# Altium schematic sheet main.SchDoc(main)
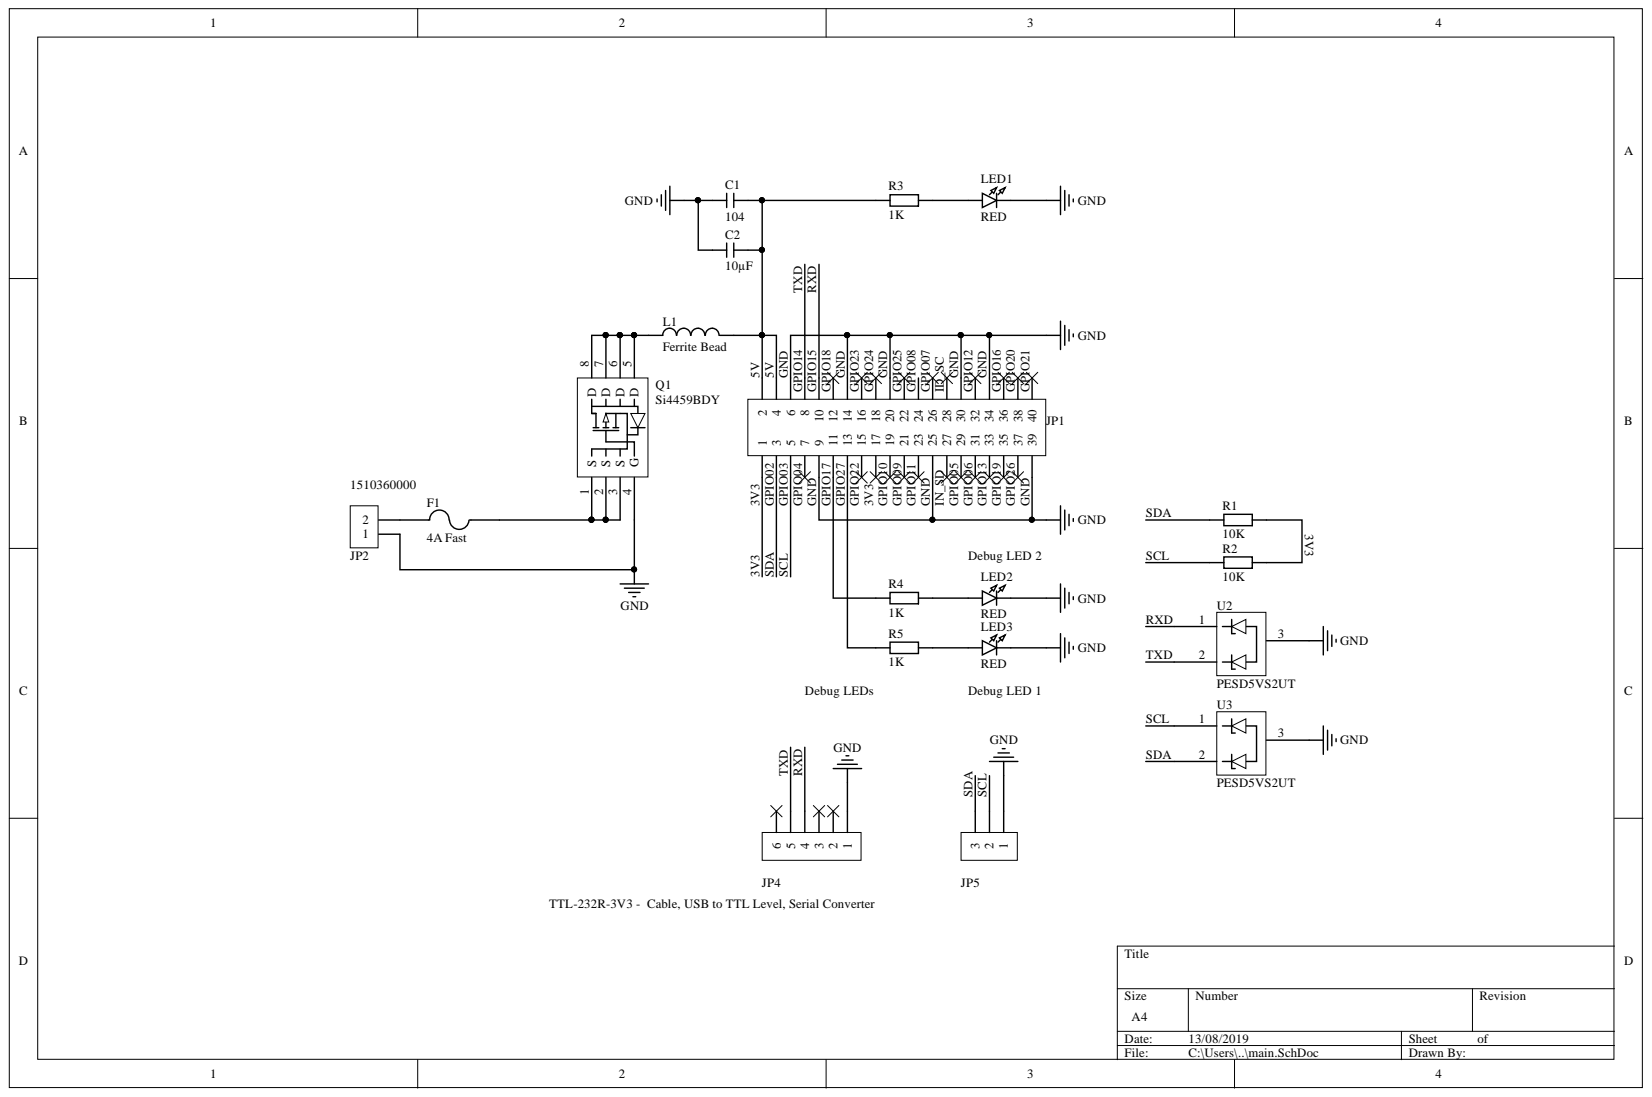
[diagram p0: main.SchDoc]

## Parts
C1  104  [C 0603_L]
C2  10µF  [C 1210_L]
F1  4A Fast  [Omni-blok]
JP1  Header 2X11  [HDR2.54-LI-2x20P]  (value not drawn)
JP2  HDR-1X2  [KF2EDGK5.08-WI-2P]  (value not drawn)
JP4  HDR-1X6  [HDR2.54-LI-6P]  (value not drawn)
JP5  HDR-1X3  [HDR2.54-LI-3P]  (value not drawn)
L1  Ferrite Bead  [L 0805_L]
LED1  RED  [LED 0805R]
LED2  RED  [LED 0805R]
LED3  RED  [LED 0805R]
Q1  Si4459BDY  [SOP8_N]
R1  10K  [R 0805_L]
R2  10K  [R 0805_L]
R3  1K  [R 0805_L]
R4  1K  [R 0805_L]
R5  1K  [R 0805_L]
U2  PESD5VS2UT  [SOT23-3N]
U3  PESD5VS2UT  [SOT23-3N]

## Nets
GND: C1.2 C2.2 JP1.6 JP1.9 JP1.14 JP1.20 JP1.25 JP1.30 JP1.34 JP1.39 JP2.1 JP4.1 JP5.1 LED1.2 LED2.2 LED3.2 Q1.4(G) U2.3 U3.3
3V3: JP1.1 R1.2 R2.2
RXD: JP1.10 JP4.4 U2.1
SCL: JP1.5 JP5.2 R2.1 U3.1
SDA: JP1.3 JP5.3 R1.1 U3.2
TXD: JP1.8 JP4.5 U2.2
NetC1_1: C1.1 C2.1 JP1.2 JP1.4 L1.2 R3.1
NetF1_1: F1.1 JP2.2
NetF1_2: F1.2 Q1.1(S) Q1.2(S) Q1.3(S)
NetJP1_11: JP1.11 R4.1
NetJP1_13: JP1.13 R5.1
NetL1_1: L1.1 Q1.5(D) Q1.6(D) Q1.7(D) Q1.8(D)
NetLED1_1: LED1.1 R3.2
NetLED2_1: LED2.1 R4.2
NetLED3_1: LED3.1 R5.2

Not connected: JP1 7 12 15 16 17 18 19 21 22 23 24 26 27 28 29 31 32 33 35 36 37 38 40; JP4 2 3 6

## Library data of the parts
C1 C2 = C: 无极性贴片电容; 版权归属: 源创客; 学习资源: www.ooocke.com; Library Name: 3.1   - 电阻-电容-电感.SchLib; Component Kind: Standard; BOM名称: 无极性贴片电容
F1 = 0154004.DRT: FUSE, 0805 SMD, 4A; Manufacturer: LITTLEFUSE; Tolerance: ± 1%; Current (Amps): 2; Value: 4A Fast; Supplier1 Part Number: 1817186; Supplier1: Onecall; Pacakge / Case: omni-blok; Manufacturer Part Number: 0154004.DRT; Library Name: Schlib1.SchLib; Component Kind: Standard; Category: FUSE
JP1 = Header 20X2: 20*2P接插件; 版权归属: 源创客; 学习资源: www.ooocke.com; Library Name: 4.5   - 接插件-脚距2.54.SchLib; Component Kind: Standard; BOM名称: 20*2P接插件
JP2 = Header 2: 2P接插件; 版权归属: 源创客; 学习资源: www.ooocke.com; Library Name: 4.11 - 接插件-脚距5.08.SchLib; Component Kind: Standard; BOM名称: 2P接插件
JP4 = Header 6: 6P接插件; 版权归属: 源创客; 学习资源: www.ooocke.com; Library Name: 4.6   - 接插件-脚距2.54.SchLib; Component Kind: Standard; BOM名称: 6P接插件
JP5 = Header 3: 3P接插件; 版权归属: 源创客; 学习资源: www.ooocke.com; Library Name: 4.6   - 接插件-脚距2.54.SchLib; Component Kind: Standard; BOM名称: 3P接插件
L1 = L: 小功率贴片电感; 版权归属: 源创客; 学习资源: www.ooocke.com; Library Name: 3.1   - 电阻-电容-电感.SchLib; Component Kind: Standard; BOM名称: 小功率贴片电感
LED1-LED3 = LED-SMD: 贴片LED; 版权归属: 源创客; 学习资源: www.ooocke.com; Library Name: 9.1   - LED.SchLib; Component Kind: Standard; BOM名称: 贴片LED
Q1 = Si4459BDY: P-Channel 30 V (D-S) MOSFET; Library Name: Schlib1.SchLib; Component Kind: Standard
R1-R5 = R: 贴片电阻; 版权归属: 源创客; 学习资源: www.ooocke.com; Library Name: 3.1   - 电阻-电容-电感.SchLib; Component Kind: Standard; BOM名称: 贴片电阻
U2 U3 = PESD5VS2UT: Double ESD protection diodes in SOT23 package; Library Name: Schlib1.SchLib; Component Kind: Standard
